# Altium schematic sheet Buzzer.SchDoc(Buzzer)
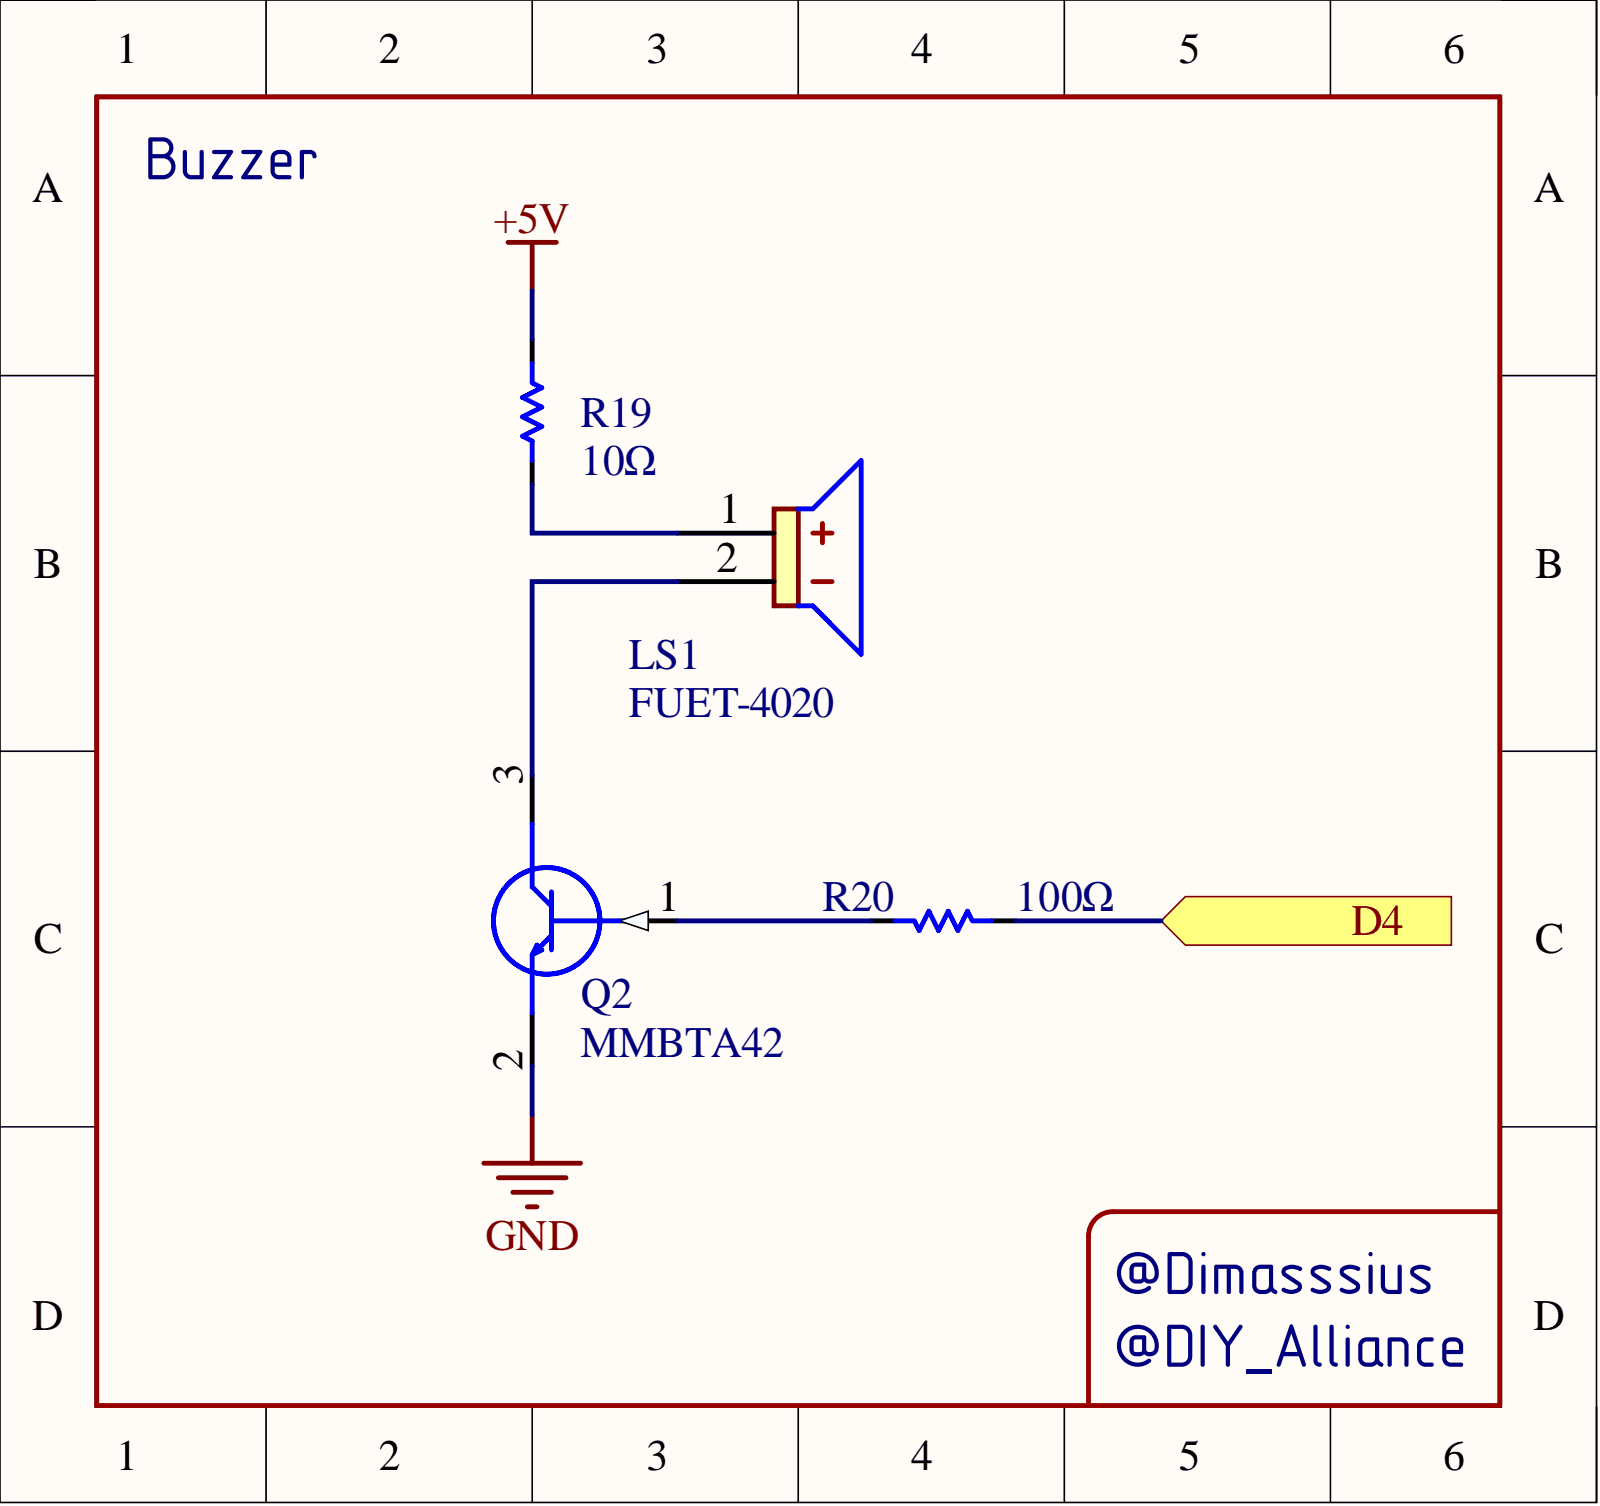
[diagram p0: Buzzer.SchDoc]

## Parts
LS1  FUET-4020  [FUET-4020]
Q2  MMBTA42  [SOT105P255X140-3N]
R19  10Ω  [RES_SMD_R0603_(M1608)_R0402A_(M1005A)]
R20  100Ω  [RES_SMD_R0603_(M1608)_R0402A_(M1005A)]

## Ports
D4

## Nets
+5V: R19.1
GND: Q2.2
D4: R20.1 ; port D4
NetLS1_1: LS1.1 R19.2
NetLS1_2: LS1.2 Q2.3
NetQ2_1: Q2.1 R20.2

## Library data of the parts
LS1 = FUET-4020: Buzzer, SMD, 4x4mm, 65dB, Passive (Externally Driven), Electromagnetic, 4kHz, Buzzers ROHS; Manufacturer_Name: Same Sky; Manufacturer_Part_Number: CMT-4023S-SMT-TR; Arrow Part Number: CMT-4023S-SMT-TR; Arrow Price/Stock: https://www.arrow.com/en/products/cmt-4023s-smt-tr/cui-devices?utm_currency=USD&region=nac; Component Kind: Standard; Datasheet Link: https://www.sameskydevices.com/product/resource/cmt-4023s-smt-tr.pdf; Library Name: SamacSys.SchLib; Mouser Part Number: 490-CMT-4023S-SMT-TR; Mouser Price/Stock: https://www.mouser.co.uk/ProductDetail/CUI-Devices/CMT-4023S-SMT-TR?qs=qCxwlXJ4fnxjBoOShJwOAQ%3D%3D; Value: FUET-4020
Q2 = MMBTA42: Transistor Bipolar (BJT), NPN, SOT-23, 300V, 225mW, 25@10mA, 10V, 500mA, NPN Bipolar (BJT), ROHS; Component Kind: Standard; Datasheet Link: http://www.st.com/web/en/resource/technical/document/datasheet/CD00002980.pdf; Height: 1.4mm; Library Name: SamacSys.SchLib; Value: MMBTA42
R19 = RES_SMD_10Ω: Resistor, Thick Film Resistor, SMD, 10Ω, ±5%, Chip Resistor - Surface Mount, ROHS; Component Kind: Standard; Library Name: Resistors.SchLib; Value: 10Ω
R20 = RES_SMD_100Ω: Resistor, Thick Film Resistor, SMD, 100Ω, ±5%, Chip Resistor - Surface Mount, ROHS; Component Kind: Standard; Library Name: Resistors.SchLib; Value: 100Ω
